# Altium schematic sheet 03_UI_Outputs.SchDoc(03_UI_Outputs)
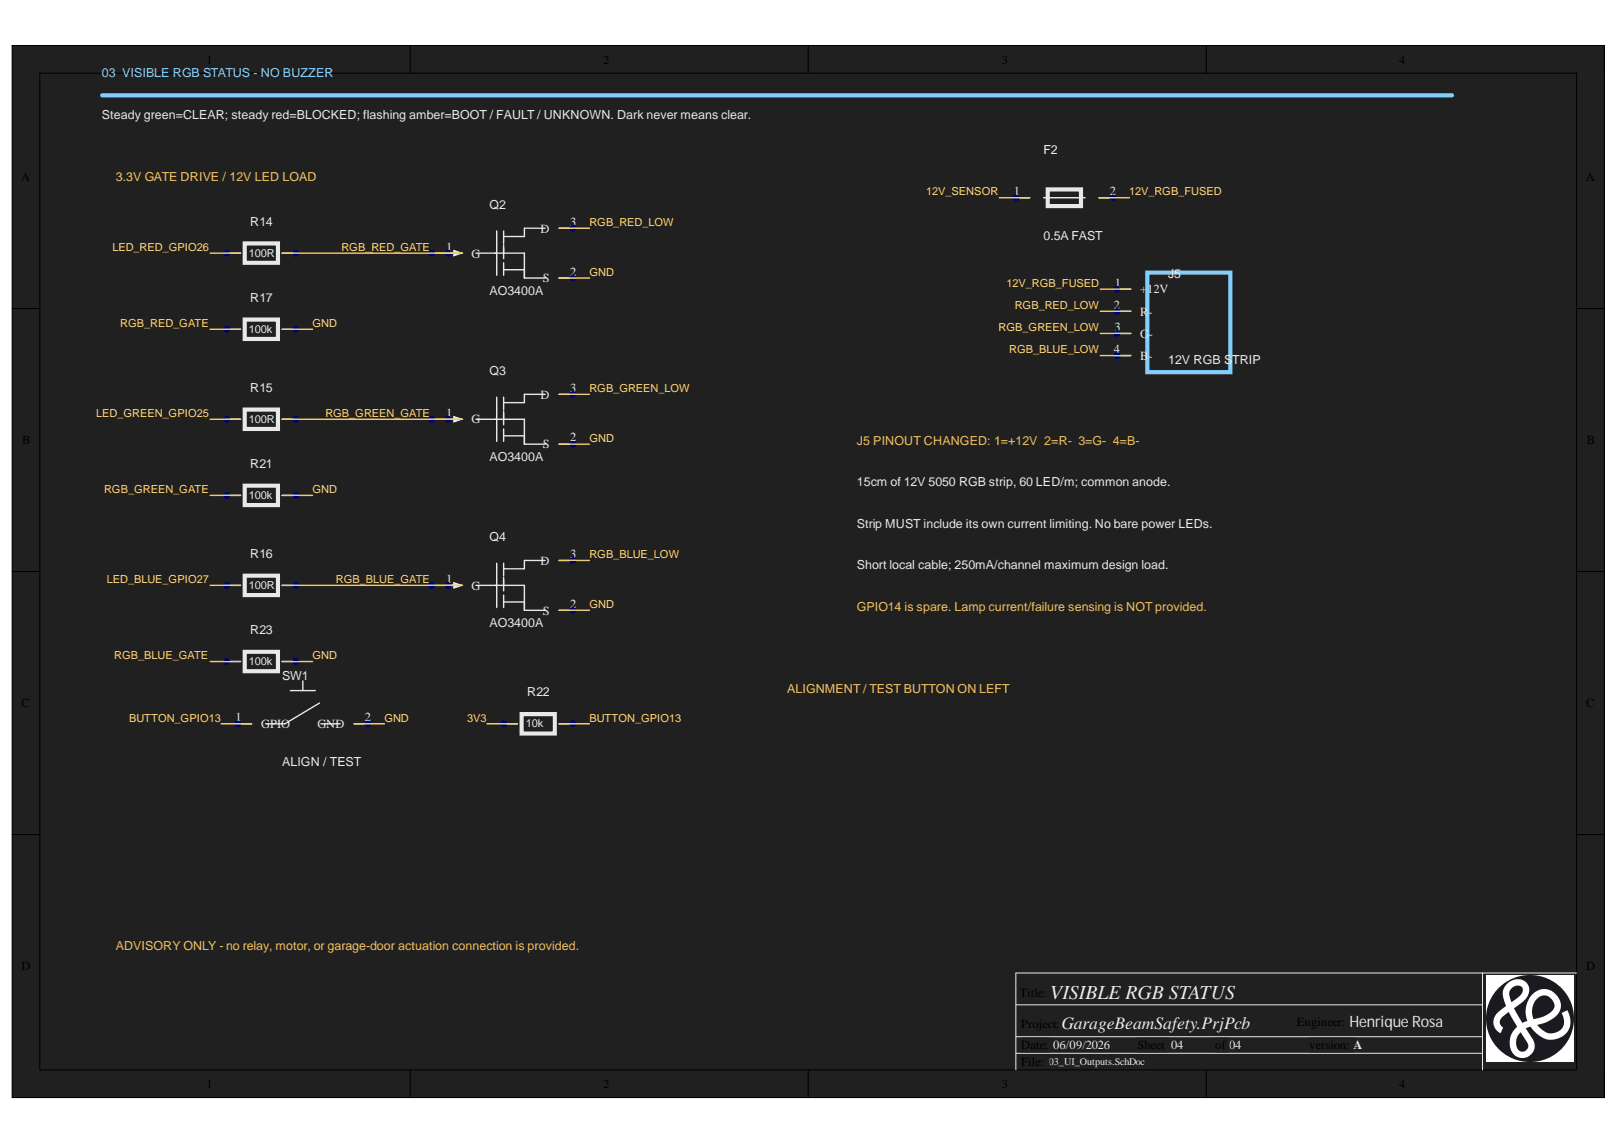
[diagram p0: 03_UI_Outputs.SchDoc]

## Parts
F2  0.5A FAST
J5  12V RGB STRIP
Q2  AO3400A
Q3  AO3400A
Q4  AO3400A
R14  100R
R15  100R
R16  100R
R17  100k
R21  100k
R22  10k
R23  100k
SW1  ALIGN / TEST

## Nets
3V3: R22.1
12V_RGB_FUSED: F2.2 J5.1(+12V)
12V_SENSOR: F2.1
BUTTON_GPIO13: R22.2 SW1.1
GND: Q2.2 Q3.2 Q4.2 R17.2 R21.2 R23.2 SW1.2
LED_BLUE_GPIO27: R16.1
LED_GREEN_GPIO25: R15.1
LED_RED_GPIO26: R14.1
RGB_BLUE_GATE: Q4.1 R16.2 R23.1
RGB_BLUE_LOW: J5.4(B-) Q4.3
RGB_GREEN_GATE: Q3.1 R15.2 R21.1
RGB_GREEN_LOW: J5.3(G-) Q3.3
RGB_RED_GATE: Q2.1 R14.2 R17.1
RGB_RED_LOW: J5.2(R-) Q2.3

## Library data of the parts
F2 = (no symbol name): RGB cable branch fuse; verify fault clearing with selected supply; Manufacturer: Littelfuse; Manufacturer Part Number: 0451.500MRL; CAD status: Symbol ready; footprint and STEP verification pending; Intended footprint: FUSE_2410; Populate: Yes; Component Kind: Standard; Package: FUSE_2410
J5 = (no symbol name): Common-anode analogue RGB strip; NOT addressable; Manufacturer: Phoenix Contact; Manufacturer Part Number: 1729144; CAD status: Symbol ready; footprint and STEP verification pending; Intended footprint: TERM_4_508; Populate: Yes; Component Kind: Standard; Package: TERM_4_508
Q2-Q4 = (no symbol name): 30V NMOS; Rds(on) specified at Vgs=2.5V; limit load to 250mA/channel; Manufacturer: Alpha and Omega Semiconductor; 3D asset: lib/upstream-kicad/SOT-23.step; downloaded, native link pending; CAD status: Symbol ready; footprint and STEP verification pending; Intended footprint: Package_TO_SOT_SMD:SOT-23; Populate: Yes; Component Kind: Standard; Datasheet: https://www.aosmd.com/sites/default/files/res/datasheets/AO3400A.pdf; Manufacturer Part Number: AO3400A; Package: Package_TO_SOT_SMD:SOT-23
R14-R16 = Res3: MOSFET gate series resistor; Manufacturer: Yageo; Manufacturer Part Number: RC0805FR-07100RL; CAD status: Symbol ready; footprint and STEP verification pending; cod.: 185070; Grupo: 01; Intended footprint: R0805; Symbol source: Conventional symbol reused from ENERGY.SchDoc; Valor: 4k7; Component Kind: Standard; Library Name: Miscellaneous Devices.IntLib
R17 R21 R23 = Res3: Gate-source pull-down; off while ESP32 resets; Manufacturer: Yageo; Manufacturer Part Number: RC0805FR-07100KL; CAD status: Symbol ready; footprint and STEP verification pending; cod.: 185070; Grupo: 01; Intended footprint: R0805; Symbol source: Conventional symbol reused from ENERGY.SchDoc; Valor: 4k7; Component Kind: Standard; Library Name: Miscellaneous Devices.IntLib
R22 = Res3: Test button external pull-up; Manufacturer: Yageo; Manufacturer Part Number: RC0805FR-0710KL; CAD status: Symbol ready; footprint and STEP verification pending; cod.: 185070; Grupo: 01; Intended footprint: 0805; Symbol source: Conventional symbol reused from ENERGY.SchDoc; Valor: 4k7; Component Kind: Standard; Library Name: Miscellaneous Devices.IntLib
SW1 = (no symbol name): Momentary test switch; Manufacturer: Omron; Manufacturer Part Number: B3FS-1000P; CAD status: Symbol ready; footprint and STEP verification pending; Intended footprint: SW_SMD_6X6; Populate: Yes; Component Kind: Standard; Package: SW_SMD_6X6
